# Altium schematic sheet Main.SchDoc(Main)
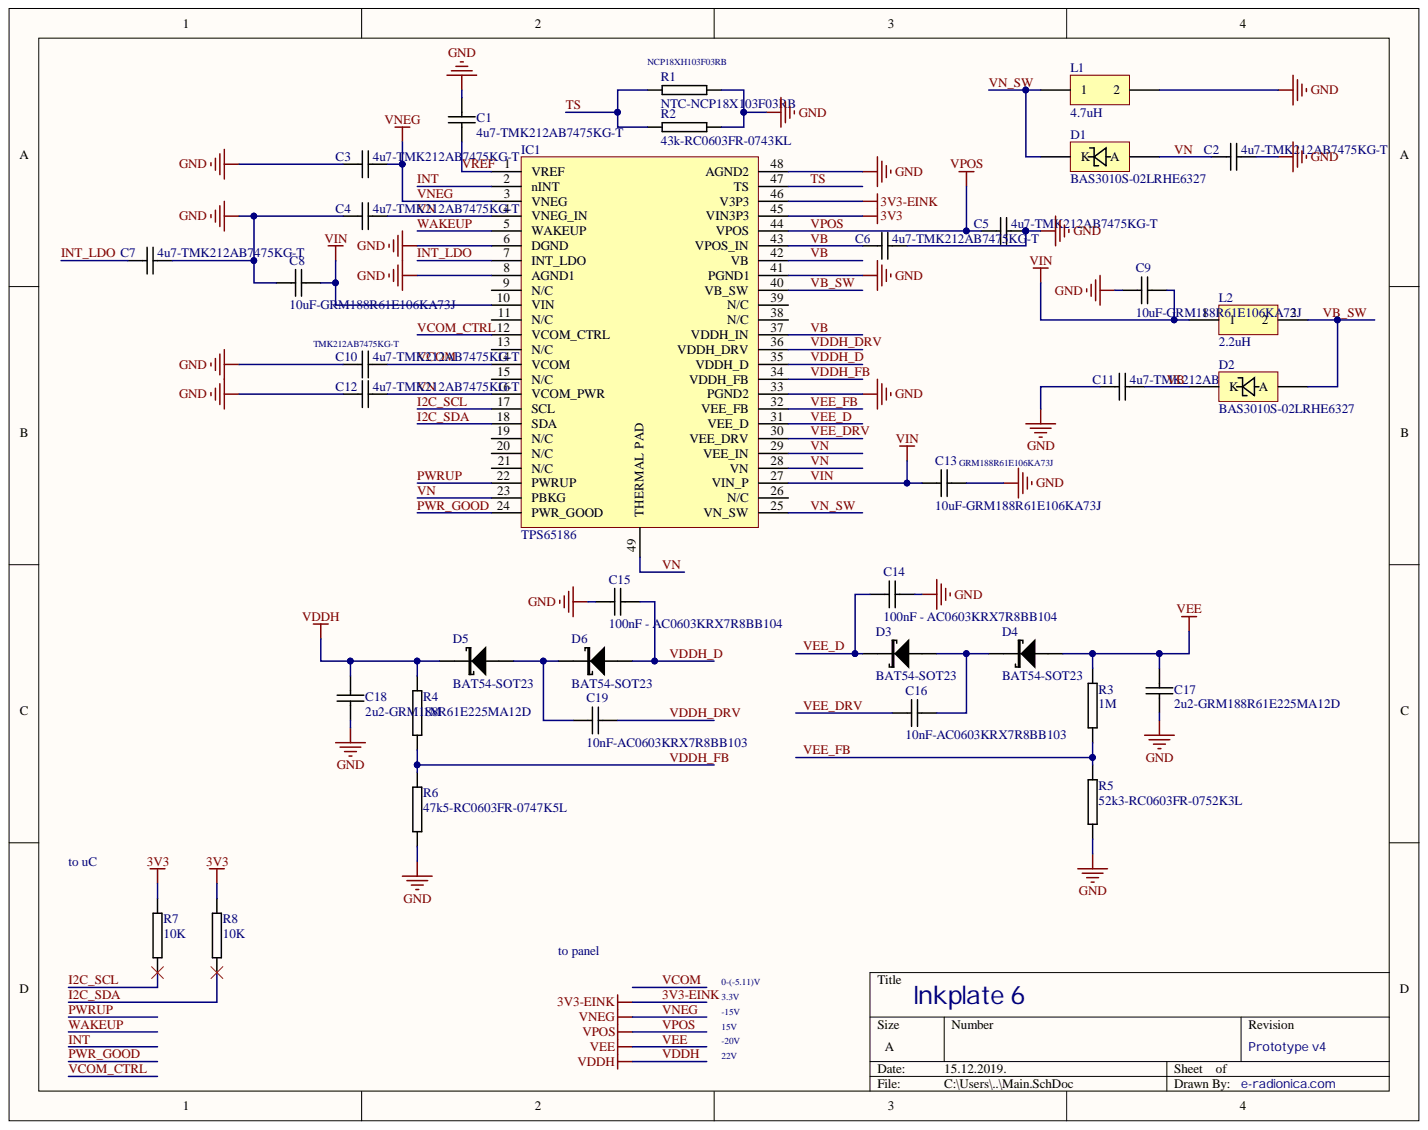
[diagram p0: Main.SchDoc]

## Parts
C1  4u7-TMK212AB7475KG-T  [0805C-GENERIC]
C2  4u7-TMK212AB7475KG-T  [0805C-GENERIC]
C3  4u7-TMK212AB7475KG-T  [0805C-GENERIC]
C4  4u7-TMK212AB7475KG-T  [0805C-GENERIC]
C5  4u7-TMK212AB7475KG-T  [0805C-GENERIC]
C6  4u7-TMK212AB7475KG-T  [0805C-GENERIC]
C7  4u7-TMK212AB7475KG-T  [0805C-GENERIC]
C8  10uF-GRM188R61E106KA73J  [0603C-GENERIC]
C9  10uF-GRM188R61E106KA73J  [0603C-GENERIC]
C10  4u7-TMK212AB7475KG-T  [0805C-GENERIC]
C11  4u7-TMK212AB7475KG-T  [0805C-GENERIC]
C12  4u7-TMK212AB7475KG-T  [0805C-GENERIC]
C13  10uF-GRM188R61E106KA73J  [0603C-GENERIC]
C14  100nF - AC0603KRX7R8BB104  [0603C-GENERIC]
C15  100nF - AC0603KRX7R8BB104  [0603C-GENERIC]
C16  10nF-AC0603KRX7R8BB103  [0603C-GENERIC]
C17  2u2-GRM188R61E225MA12D  [0603C-2U2]
C18  2u2-GRM188R61E225MA12D  [0603C-2U2]
C19  10nF-AC0603KRX7R8BB103  [0603C-GENERIC]
D1  BAS3010S-02LRHE6327  [BAS3010S-02LRHE6327]
D2  BAS3010S-02LRHE6327  [BAS3010S-02LRHE6327]
D3  BAT54-SOT23  [SOT23-123]
D4  BAT54-SOT23  [SOT23-123]
D5  BAT54-SOT23  [SOT23-123]
D6  BAT54-SOT23  [SOT23-123]
IC1  TPS65186  [TPS65186_QFN_48]
L1  4.7uH  [LQH44PN4R7MP0_Inductor]
L2  2.2uH  [NR4012T2R2M_Fixed Inductor]
R1  NTC-NCP18X103F03RB  [0603R-GENERIC]
R2  43k-RC0603FR-0743KL  [0603R-GENERIC]
R3  1M  [0603R-GENERIC]
R4  1M  [0603R-GENERIC]
R5  52k3-RC0603FR-0752K3L  [0603R-GENERIC]
R6  47k5-RC0603FR-0747K5L  [0603R-GENERIC]
R7  10K  [0603R-10K]
R8  10K  [0603R-10K]

## Nets
3V3: IC1.45(VIN3P3) R7.1 R8.1
GND: C1.2 C2.2 C3.1 C4.1 C5.2 C6.2 C7.2 C8.1 C9.2 C10.1 C11.1 C12.1 C13.2 C14.2 C15.2 C17.2 C18.2 IC1.6(DGND) IC1.8(AGND1) IC1.33(PGND2) IC1.41(PGND1) IC1.48(AGND2) L1.2 R1.2 R2.2 R5.2 R6.2
3V3-EINK: IC1.46(V3P3)
I2C_SCL: IC1.17(SCL) R7.2
I2C_SDA: IC1.18(SDA) R8.2
INT: IC1.2(nINT)
INT_LDO: C7.1 IC1.7(INT_LDO)
PWR_GOOD: IC1.24(PWR_GOOD)
PWRUP: IC1.22(PWRUP)
TS: IC1.47(TS) R1.1 R2.1
VB: C6.1 C11.2 D2.K IC1.37(VDDH_IN) IC1.42(VB) IC1.43(VPOS_IN)
VB_SW: D2.A IC1.40(VB_SW) L2.2
VCOM: C10.2 IC1.14(VCOM)
VCOM_CTRL: IC1.12(VCOM_CTRL)
VDDH: C18.1 D5.3 R4.1
VDDH_D: C15.1 D6.1 IC1.35(VDDH_D)
VDDH_DRV: C19.1 IC1.36(VDDH_DRV)
VDDH_FB: IC1.34(VDDH_FB) R4.2 R6.1
VEE: C17.1 D4.1 R3.1
VEE_D: C14.1 D3.3 IC1.31(VEE_D)
VEE_DRV: C16.1 IC1.30(VEE_DRV)
VEE_FB: IC1.32(VEE_FB) R3.2 R5.1
VIN: C8.2 C9.1 C13.1 IC1.10(VIN) IC1.27(VIN_P) L2.1
VN: C2.1 C4.2 C12.2 D1.A IC1.4(VNEG_IN) IC1.16(VCOM_PWR) IC1.23(PBKG) IC1.28(VN) IC1.29(VEE_IN) IC1.49(THERMAL_PAD)
VN_SW: D1.K IC1.25(VN_SW) L1.1
VNEG: C3.2 IC1.3(VNEG)
VPOS: C5.1 IC1.44(VPOS)
VREF: C1.1 IC1.1(VREF)
WAKEUP: IC1.5(WAKEUP)
NetC16_2: C16.2 D3.1 D4.3
NetC19_2: C19.2 D5.1 D6.3

Not connected: IC1 9(N/C) 11(N/C) 13(N/C) 15(N/C) 19(N/C) 20(N/C) 21(N/C) 26(N/C) 38(N/C) 39(N/C)

## Library data of the parts
C1-C7 C11 = 0805C-4U7-25V-X5R-TDK: TMK212AB7475KG-T; Manufacturer Part Number: C2012X5R1E475K; Manufacturer: TDK Corporation; Voltage - Rated: 25V; Tolerance: ±10%; Temperature Coefficient: X5R; REEL: C102; Capacitance: 4.7µF; Thickness: 1.25mm; Supplier Part Number 1: 445-4116-1-ND; Supplier Part Number: 445-4116-1-ND; Supplier 1: Digi-Key; Supplier: Digi-Key; RoHS: RoHS Compliant; Packaging: Cut Tape (CT); Package / Case: 0805 (2012 Metric); Operating Temperature: -55°C ~ 85°C; Mounting Type: Surface Mount, MLCC; Library Name: vh_altium_library.intLib; Lead Spacing: -; Features: General Purpose; Component Kind: Standard; Category: Capacitors
C8 C9 = 0603C-10UF-10V-X5R-TDK: GRM188R61E106KA73J; Manufacturer Part Number: C1608X5R0J106M; Manufacturer: TDK Corporation; Reel: C31; Supplier Part Number 1: 445-4112-2-ND; Supplier 1: Digi-Key; Library Name: vh_altium_library.intLib; Component Kind: Standard
C10 C12 = 0805C-4U7-25V-X5R-TDK: CAPACITOR 0805 CERAMIC; Manufacturer Part Number: C2012X5R1E475K; Manufacturer: TDK Corporation; Voltage - Rated: 25V; Tolerance: ±10%; Temperature Coefficient: X5R; REEL: C102; Capacitance: 4.7µF; Thickness: 1.25mm; Supplier Part Number 1: 445-4116-1-ND; Supplier Part Number: 445-4116-1-ND; Supplier 1: Digi-Key; Supplier: Digi-Key; RoHS: RoHS Compliant; Packaging: Cut Tape (CT); Package / Case: 0805 (2012 Metric); Operating Temperature: -55°C ~ 85°C; Mounting Type: Surface Mount, MLCC; Library Name: vh_altium_library.intLib; Lead Spacing: -; Features: General Purpose; Component Kind: Standard; Category: Capacitors
C13 = 0603C-10UF-10V-X5R-TDK: GRM188R61E106KA73J; Library Name: vh_altium_library.intLib; Component Kind: Standard
C14 C15 = 0603C-100NF-25V-X7R-TDK: CAPACITOR 0603 CERAMIC; Manufacturer Part Number: C1608X7R1E104K; Manufacturer: TDK Corporation; Reel: C12; Supplier Part Number 1: 445-1316-2-ND; Supplier 1: Digi-Key; Library Name: vh_altium_library.intLib; Component Kind: Standard
C16 C19 = 0603C-10NF-50V-X7R-MUR: AC0603KRX7R8BB103; Manufacturer Part Number: GRM188R71H103KA01D; Manufacturer: Murata Electronics North America; Reel: C22; Supplier Part Number 1: 490-1512-2-ND; Supplier 1: Digi-Key; Library Name: vh_altium_library.intLib; Component Kind: Standard
C17 C18 = 0603C-2U2-10V-X5R-TDK: GRM188R61E225MA12D; Manufacturer Part Number: C1608X5R1A225K; Manufacturer: TDK Corporation; Reel: C11; Supplier Part Number 1: 445-5166-2-ND; Supplier 1: Digi-Key; Library Name: vh_altium_library.intLib; Component Kind: Standard
D1 D2 = BAS3010S-02LRHE6327: DIODE SCHOTTKY 30V 1A TSLP-2; Manufacturer: Infineon; Peak Reverse Current: 300uA; Peak Non-Repetitive Surge Current: 4A; Element Configuration: Single; Supplier Part Number 1: BAS3010S02LRHE6327XTSA1CT-ND; Supplier 1: Digi-Key; Stock 1: 14868; Radiation Hardening: No; Pricing 1: 1=0.42, 10=0.341, 100=0.2324, 500=0.17428, 1000=0.13072 (USD); Packaging: Tape and Reel; Package Quantity: 1; Number of Pins: 2; Mount: Surface Mount; Min Operating Temperature: -55°C; Max Surge Current: 4A; Max Reverse Voltage (DC): 30V; Max Reverse Leakage Current: 300uA; Max Repetitive Reverse Voltage (Vrrm): 30V; Max Operating Temperature: 150°C; Manufacturer Part Number: BAS3010S-02LRHE6327; Library Name: Schlib17052019.SchLib; Forward Current: 1A; Contact Plating: Gold; ComponentLink1URL: http://www.infineon.com/dgdl/bas3010s-02lrh.pdf?folderId=db3a304313d846880113def5812204a1&fileId=db3a30431ddc9372011e24fd0b124647&src-supplier=Avnet; ComponentLink1Description: http://www.infineon.com/dgdl/bas3010s-02lrh.pdf?folderId=db3a304313d846880113def5812204a1&fileId=db3a30431ddc9372011e24fd0b124647&src-supplier=Avnet; Component Kind: Standard; Category: Schottky Diodes; Average Rectified Current: 1A
D3-D6 = BAT54-SOT23: SINGLE SHOTTKY DIODE; Manufacturer Part Number: BAT54,215; Manufacturer: NXP Semiconductors; Voltage - Forward (Vf) (Max) @ If: 800mV @ 100mA; Voltage - DC Reverse (Vr) (Max): 30V; Speed: Small Signal =< 200mA (Io), Any Speed; Reverse Recovery Time (trr): 5ns; Diode Type: Schottky; Current - Reverse Leakage @ Vr: 2µA @ 25V; Current - Average Rectified (Io): 200mA (DC); Capacitance @ Vr, F: 10pF @ 1V, 1MHz; Supplier Part Number 1: 568-1610-1-ND; Supplier Part Number: 568-1610-1-ND; Supplier 1: Digi-Key; Supplier: Digi-Key; RoHS: RoHS Compliant; Packaging: Cut Tape (CT); Package / Case: SOT-23-3, TO-236-3, Micro3™, SSD3, SST3; Mounting Type: Surface Mount; Library Name: vh_altium_library.intLib; Component Kind: Standard; Category: Discrete Semiconductor Products
IC1 = TPS65186RGZR: IC PWR MGMT E INK 48VQFN; Manufacturer: Texas Instruments; Quiescent Current: 5.5mA; Power Dissipation: 2W; Output Current: 120mA; Operating Supply Voltage: 6V; Min Input Voltage: 3V; Type: Integrated Circuit (IC); Supplier Part Number 1: 296-29566-1-ND; Supplier 1: Digi-Key; Stock 1: 2619; Pricing 1: 1=4.86, 10=4.368, 100=3.5791, 500=3.04686, 1000=2.56964 (USD); Packaging: Tape and Reel; Package Quantity: 2500; Operating Supply Current: 5.5mA; Number of Pins: 48; Min Operating Temperature: -40°C; Max Operating Temperature: 85°C; Max Input Voltage: 6V; Manufacturer Part Number: TPS65186RGZR; Library Name: Schlib17052019.SchLib; Length: 7mm; Lead Free: Lead Free; Input Current: 5.5mA; Height: 0.9mm; ComponentLink2URL: http://www.ti.com/lit/ds/symlink/tps65186.pdf?src-supplier=Digi-Key; ComponentLink2Description: http://www.ti.com/lit/ds/symlink/tps65186.pdf?src-supplier=Digi-Key; ComponentLink1URL: http://www.ti.com/general/docs/lit/getliterature.tsp?genericPartNumber=TPS65186&&fileType=pdf&src-supplier=Avnet; ComponentLink1Description: http://www.ti.com/general/docs/lit/getliterature.tsp?genericPartNumber=TPS65186&&fileType=pdf&src-supplier=Avnet; Component Kind: Standard; Category: PMIC - Power Management; Case/Package: VQFN
L1 = LQH44PN4R7MP0L: Inductor Power Chip Shielded Wirewound 4.7uH 20% 1MHz Ferrite 1.7A 96mOhm DCR 1515 Embossed T/R; Manufacturer: Murata; Series Resistance: 80mR; Self Resonant Frequency: 40MHz; DC Resistance (DCR): 96mR; Width: 0.157inch; Weight: 0.003527oz; Tolerance: 20%; Test Frequency: 1MHz; Termination: SMD/SMT; Supplier Part Number 1: 490-5328-1-ND; Supplier 1: Digi-Key; Stock 1: 18102; Shielding: Shielded; Saturation Current: 1.7A; REACH SVHC: No SVHC; Radiation Hardening: No; Pricing 1: 1=0.43, 10=0.379, 100=0.2708, 500=0.2275 (USD); Packaging: Tape and Reel; Package Quantity: 1; Mount: Surface Mount; Min Operating Temperature: -40°C; Military Standard: Not; Max Operating Temperature: 85°C; Max DC Current: 1.7A; Manufacturer Part Number: LQH44PN4R7MP0L; Library Name: Schlib17052019.SchLib; Length: 4mm; Lead Free: Lead Free; Inductance Tolerance: 20%; Inductance: 4.7uH; Height - Seated (Max): 0.071inch; Height: 0.9mm; Depth: 4.2mm; DC Current: 1.7A; Current Rating: 1.7A; Core Material: Ferrite; Composition: Wirewound; ComponentLink7URL: http://www.farnell.com/datasheets/490895.pdf?src-supplier=Element14; ComponentLink7Description: http://www.farnell.com/datasheets/490895.pdf?src-supplier=Element14; ComponentLink6URL: http://search.murata.co.jp/Ceramy/image/img/PDF/ENG/L0075S0103LQH44P_P0.pdf?src-supplier=Avnet+Europe; ComponentLink6Description: http://search.murata.co.jp/Ceramy/image/img/PDF/ENG/L0075S0103LQH44P_P0.pdf?src-supplier=Avnet+Europe; ComponentLink5URL: https://www.distrelec.biz/Web/Downloads/g_/ds/lqh44pn_eng_ds.pdf?mime=application%2Fpdf&src-supplier=Distrelec; ComponentLink5Description: https://www.distrelec.biz/Web/Downloads/g_/ds/lqh44pn_eng_ds.pdf?mime=application%2Fpdf&src-supplier=Distrelec; ComponentLink4URL: http://www.mouser.com/ds/2/281/o05e-522697.pdf?src-supplier=Mouser; ComponentLink4Description: http://www.mouser.com/ds/2/281/o05e-522697.pdf?src-supplier=Mouser; ComponentLink3URL: http://psearch.murata.com/inductor/product/LQH44PN4R7MP0%23.pdf?src-supplier=Future+Electronics; ComponentLink3Description: http://psearch.murata.com/inductor/product/LQH44PN4R7MP0%23.pdf?src-supplier=Future+Electronics; ComponentLink2URL: http://search.murata.co.jp/Ceramy/image/img/PDF/ENG/L0075S0103LQH44P_P0.pdf?src-supplier=Avnet; ComponentLink2Description: http://search.murata.co.jp/Ceramy/image/img/PDF/ENG/L0075S0103LQH44P_P0.pdf?src-supplier=Avnet; ComponentLink1URL: http://components.arrow.com/part/dataview/48185372S8976820N2606?src-supplier=Arrow; ComponentLink1Description: http://components.arrow.com/part/dataview/48185372S8976820N2606?src-supplier=Arrow; Component Kind: Standard; Category: Fixed Inductors; Case Code (Metric): 4040; Case Code (Imperial): 1515; Case/Package: 1515
L2 = NR4012T2R2M: FIXED IND 2.2UH 1.2A 108 MOHM; Manufacturer: Taiyo Yuden; Tolerance: 20%; Test Frequency: 100kHz; Shielding: Shielded; Self Resonant Frequency: 66MHz; Saturation Current: 1.65A; DC Resistance (DCR): 90mR; Core Material: Ferrite; Width: 4mm; Termination: SMD/SMT; Supplier Part Number 1: 587-1661-6-ND; Supplier 1: Digi-Key; Stock 1: 1790; RMS Current (Irms): 1.2A; REACH SVHC: Unknown; Pricing 1: 1=0.44, 10=0.382, 100=0.2731, 500=0.22942, 1000=0.18573 (USD); Packaging: Cut Tape; Package Quantity: 4500; Mount: Surface Mount; Min Operating Temperature: -25°C; Max Operating Temperature: 120°C; Max DC Current: 1.65A; Manufacturer Part Number: NR4012T2R2M; Library Name: Schlib17052019.SchLib; Length: 0.157inch; Lead Free: Lead Free; Inductance Tolerance: 20%; Inductance: 2.2uH; Height - Seated (Max): 0.047inch; Height: 1.2mm; Halogen Free: Halogen Free; Current Rating: 1.2A; Composition: Wirewound; ComponentLink2URL: http://www.yuden.co.jp/productdata/catalog/en/wound04_e.pdf?src-supplier=Digi-Key; ComponentLink2Description: http://www.yuden.co.jp/productdata/catalog/en/wound04_e.pdf?src-supplier=Digi-Key; ComponentLink1URL: http://www.yuden.co.jp/productdata/catalog/en/wound04_e.pdf?src-supplier=Avnet; ComponentLink1Description: http://www.yuden.co.jp/productdata/catalog/en/wound04_e.pdf?src-supplier=Avnet; Component Kind: Standard; Category: Fixed Inductors; Case/Package: Nonstandard
R1 = R0603-GENERIC: NCP18X103F03RB; Library Name: vh_altium_library.intLib; Component Kind: Standard
R2 = R0603-GENERIC: RC0603FR-0743KL; Library Name: vh_altium_library.intLib; Component Kind: Standard
R3 R4 = 0603R-1M: GENERIC RESISTOR 0603; Manufacturer Part Number: RMCF 1/16 1M 1% R; Manufacturer: Stackpole Electronics Inc; Tolerance: ±1%; Temperature Coefficient: ±100ppm/°C; Resistance In Ohms: 1.00M; REEL: R51; Power (Watts): 0.1W, 1/10W; Supplier Part Number 1: RMCF0603FT1M00TR-ND; Supplier Part Number: RMCF1/161MFRTR-ND; Supplier 1: Digi-Key; Supplier: Digi-Key; RoHS: RoHS Compliant; Packaging: Tape & Reel (TR); Library Name: vh_altium_library.intLib; Lead Style: Surface Mount (SMD - SMT); Composition: Thick Film; Component Kind: Standard; Category: Resistors; Case: 0603 (1608 metric)
R5 = R0603-GENERIC: RC0603FR-0752K3L; Library Name: vh_altium_library.intLib; Component Kind: Standard
R6 = R0603-GENERIC: RC0603FR-0747K5L; Library Name: vh_altium_library.intLib; Component Kind: Standard
R7 R8 = 0603R-10K: GENERIC RESISTOR 0603; Manufacturer Part Number: RMCF 1/16 10K 1% R; Manufacturer: Stackpole Electronics Inc; Tolerance: ±1%; Temperature Coefficient: ±100ppm/°C; Resistance In Ohms: 10.0K; Reel: R21; Power (Watts): 0.1W, 1/10W; Supplier Part Number 1: RMCF0603FT10K0DKR-ND; Supplier Part Number: RMCF1/1610KFRDKR-ND; Supplier 1: Digi-Key; Supplier: Digi-Key; RoHS: RoHS Compliant; Packaging: Digi-Reel®; Library Name: vh_altium_library.intLib; Lead Style: Surface Mount (SMD - SMT); Composition: Thick Film; Component Kind: Standard; Category: Resistors; Case: 0603 (1608 metric)
